# Altium schematic sheet MAIN.SchDoc(MAIN)
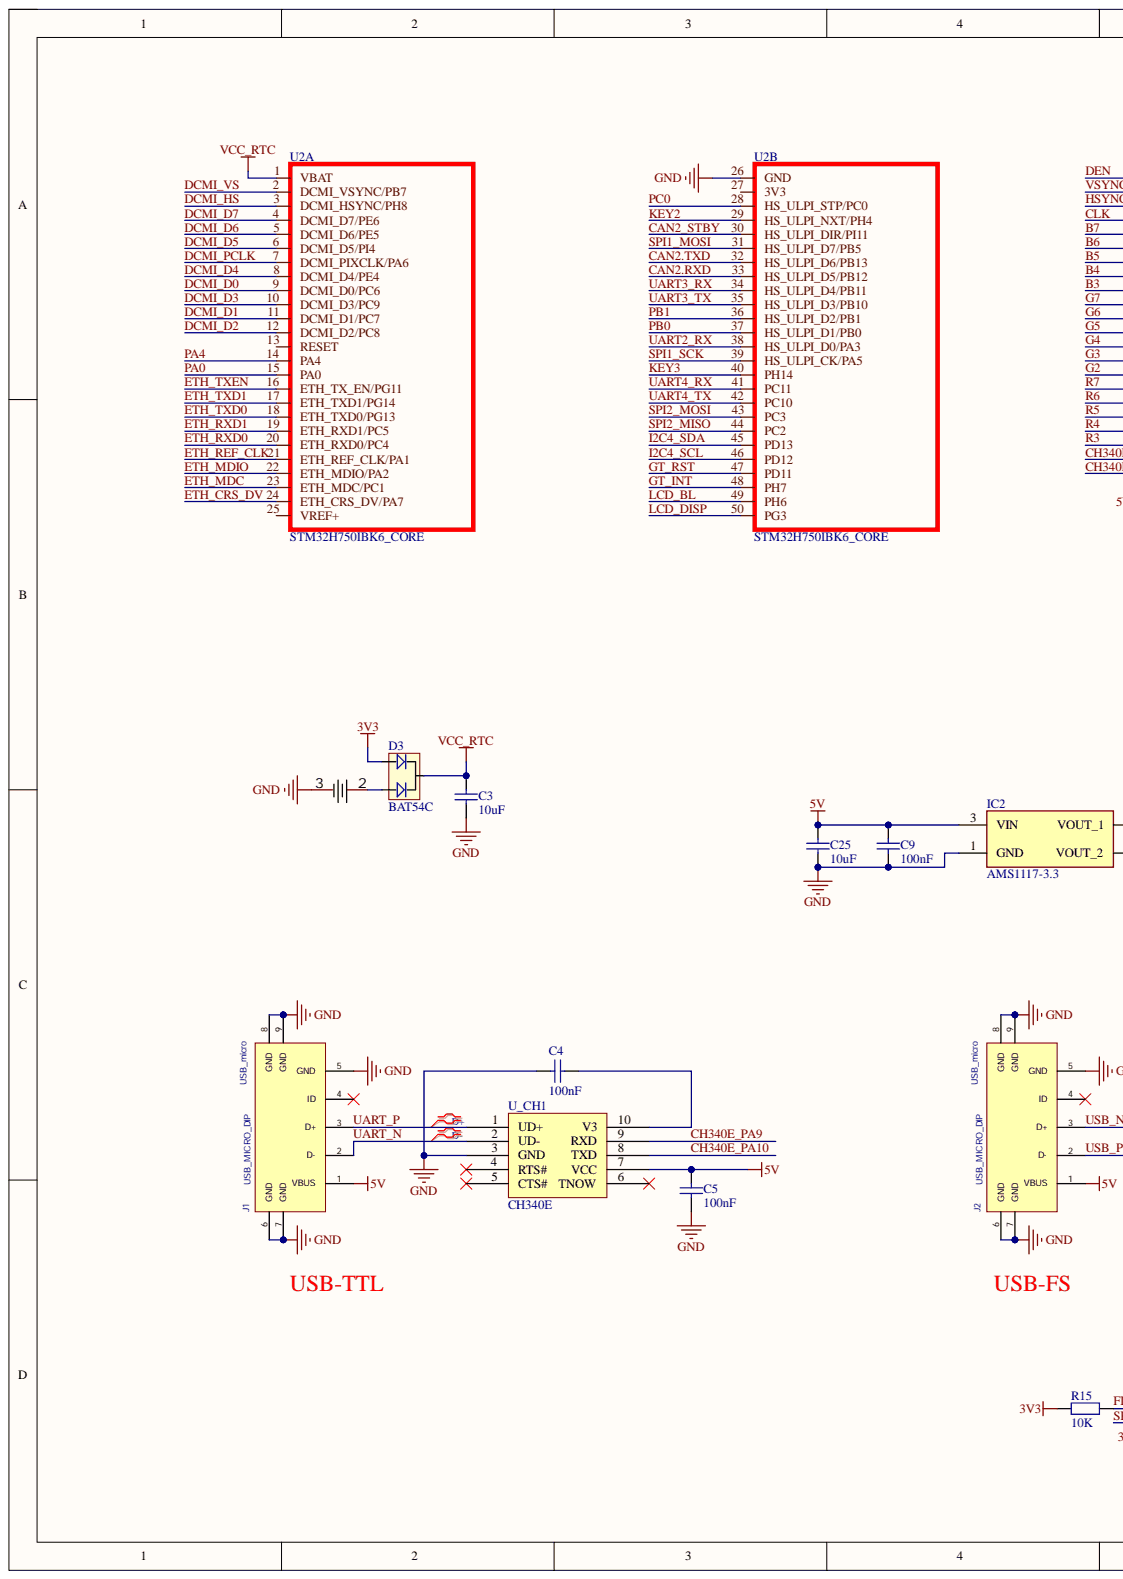
[diagram p0: MAIN.SchDoc, left]
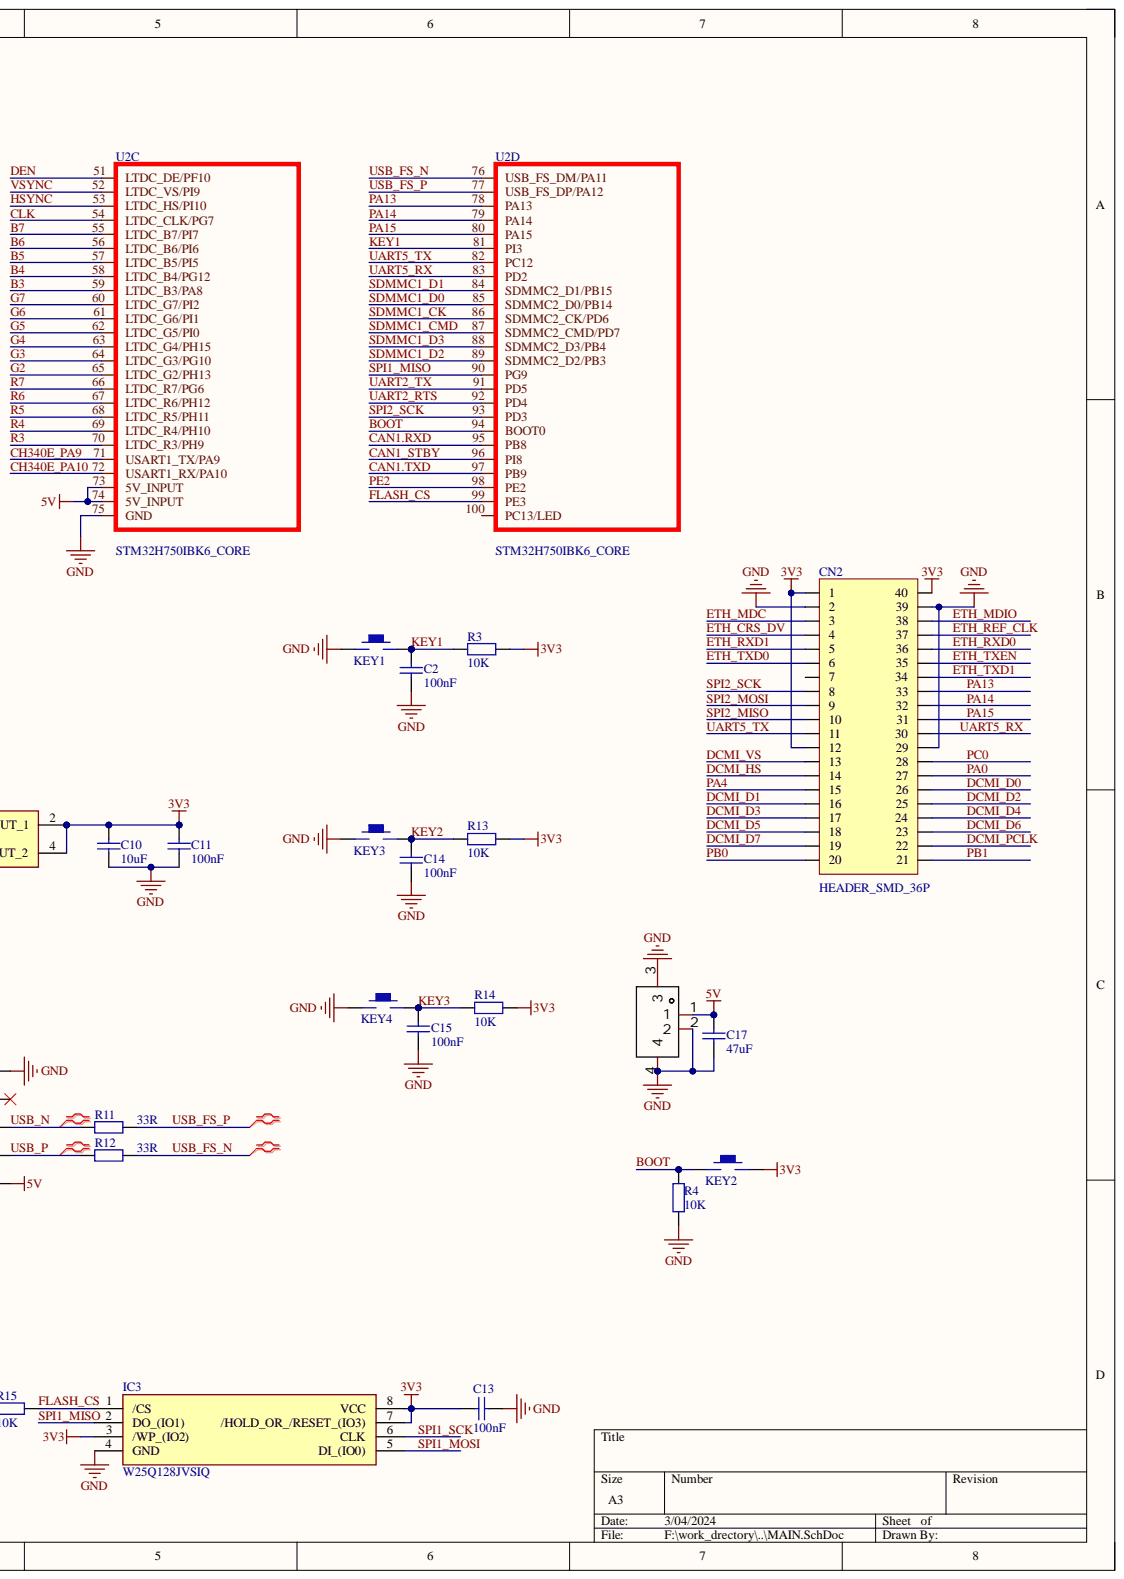
[diagram p1: MAIN.SchDoc, right]

## Parts
BT2  BS-06-B4AA002  [BAT_CR1220]  (value not drawn)
C2  100nF  [0402_C]
C3  10uF  [0603_C]
C4  100nF  [0402_C]
C5  100nF  [0402_C]
C9  100nF  [0402_C]
C10  10uF  [0603_C]
C11  100nF  [0402_C]
C13  100nF  [0402_C]
C14  100nF  [0402_C]
C15  100nF  [0402_C]
C17  47uF  [1206_C]
C25  10uF  [0603_C]
CN2  HEADER_SMD_36P  [HDR-SMD_40P-P2.54]
CN7  HC-1.25-2PWT  [CONN-SMD_2P-P1.25-HC-1.25-2PWT]  (value not drawn)
D3  BAT54C  [BAT54C215]
IC2  AMS1117-3.3  [SOT229P700X180-4N]
IC3  W25Q128JVSIQ  [SOP-8]
J1  USB_MICRO_DIP  value USB_micro  [MICRO_USB_5S]
J2  USB_MICRO_DIP  value USB_micro  [MICRO_USB_5S]
KEY1  KEY-SMD-324225  [KEY-SOP324225]  (value not drawn)
KEY2  KEY-SMD-324225  [KEY-SOP324225]  (value not drawn)
KEY3  KEY-SMD-324225  [KEY-SOP324225]  (value not drawn)
KEY4  KEY-SMD-324225  [KEY-SOP324225]  (value not drawn)
R3  10K  [0402_R]
R4  10K  [0402_R]
R11  33R  [0402_R]
R12  33R  [0402_R]
R13  10K  [0402_R]
R14  10K  [0402_R]
R15  10K  [0402_R]
U2  STM32H750IBK6_CORE  [CORE]  (parts A,B,C,D)
U_CH1  CH340E  [MSOP-10]

## Nets
3V3: C10.1 C11.1 C13.1 CN2.1 CN2.12 CN2.40 D3.1 IC2.2(VOUT_1) IC2.4(VOUT_2) IC3.3(/WP_(IO2)) IC3.7(/HOLD_OR_/RESET_(IO3)) IC3.8(VCC) KEY2.2 R3.1 R13.1 R14.1 R15.2
5V: C5.1 C9.1 C17.2 C25.1 CN7.1 IC2.3(VIN) J1.1(VBUS) J2.1(VBUS) U2.73(5V_INPUT) U2.74(5V_INPUT) U_CH1.7(VCC)
GND: BT2.3 C2.2 C3.2 C4.1 C5.2 C9.2 C10.2 C11.2 C13.2 C14.2 C15.2 C17.1 C25.2 CN2.2 CN2.29 CN2.39 CN7.2 CN7.3 CN7.4 IC2.1(GND) IC3.4(GND) J1.5(GND) J1.6(GND) J1.7(GND) J1.8(GND) J1.9(GND) J2.5(GND) J2.6(GND) J2.7(GND) J2.8(GND) J2.9(GND) KEY1.1 KEY3.1 KEY4.1 R4.2 U2.26(GND) U2.75(GND) U_CH1.3(GND)
VCC_RTC: C3.1 D3.3 U2.1(VBAT)
B3: U2.59(LTDC_B3/PA8)
B4: U2.58(LTDC_B4/PG12)
B5: U2.57(LTDC_B5/PI5)
B6: U2.56(LTDC_B6/PI6)
B7: U2.55(LTDC_B7/PI7)
BOOT: KEY2.1 R4.1 U2.94(BOOT0)
CAN1.RXD: U2.95(PB8) U2.96(PI8)  [also labelled CAN1_STBY]
CAN1.TXD: U2.97(PB9)
CAN2.RXD: U2.30(HS_ULPI_DIR/PI11) U2.33(HS_ULPI_D5/PB12)  [also labelled CAN2_STBY]
CAN2.TXD: U2.32(HS_ULPI_D6/PB13)
CH340E_PA9: U2.71(USART1_TX/PA9) U_CH1.9(RXD)
CH340E_PA10: U2.72(USART1_RX/PA10) U_CH1.8(TXD)
CLK: U2.54(LTDC_CLK/PG7)
DCMI_D0: CN2.26 U2.9(DCMI_D0/PC6)
DCMI_D1: CN2.16 U2.11(DCMI_D1/PC7)
DCMI_D2: CN2.25 U2.12(DCMI_D2/PC8)
DCMI_D3: CN2.17 U2.10(DCMI_D3/PC9)
DCMI_D4: CN2.24 U2.8(DCMI_D4/PE4)
DCMI_D5: CN2.18 U2.6(DCMI_D5/PI4)
DCMI_D6: CN2.23 U2.5(DCMI_D6/PE5)
DCMI_D7: CN2.19 U2.4(DCMI_D7/PE6)
DCMI_HS: CN2.14 U2.3(DCMI_HSYNC/PH8)
DCMI_PCLK: CN2.22 U2.7(DCMI_PIXCLK/PA6)
DCMI_VS: CN2.13 U2.2(DCMI_VSYNC/PB7)
DEN: U2.51(LTDC_DE/PF10)
ETH_CRS_DV: CN2.4 U2.24(ETH_CRS_DV/PA7)
ETH_MDC: CN2.3 U2.23(ETH_MDC/PC1)
ETH_MDIO: CN2.38 U2.22(ETH_MDIO/PA2)
ETH_REF_CLK: CN2.37 U2.21(ETH_REF_CLK/PA1)
ETH_RXD0: CN2.36 U2.20(ETH_RXD0/PC4)
ETH_RXD1: CN2.5 U2.19(ETH_RXD1/PC5)
ETH_TXD0: CN2.6 U2.18(ETH_TXD0/PG13)
ETH_TXD1: CN2.34 U2.17(ETH_TXD1/PG14)
ETH_TXEN: CN2.35 U2.16(ETH_TX_EN/PG11)
FLASH_CS: IC3.1(/CS) R15.1 U2.99(PE3)
G2: U2.65(LTDC_G2/PH13)
G3: U2.64(LTDC_G3/PG10)
G4: U2.63(LTDC_G4/PH15)
G5: U2.62(LTDC_G5/PI0)
G6: U2.61(LTDC_G6/PI1)
G7: U2.60(LTDC_G7/PI2)
GT_INT: U2.48(PH7)
GT_RST: U2.47(PD11)
HSYNC: U2.53(LTDC_HS/PI10)
I2C4_SCL: U2.46(PD12)
I2C4_SDA: U2.45(PD13)
KEY1: C2.1 KEY1.2 R3.2 U2.81(PI3)
KEY2: C14.1 KEY3.2 R13.2 U2.29(HS_ULPI_NXT/PH4)
KEY3: C15.1 KEY4.2 R14.2 U2.40(PH14)
LCD_BL: U2.49(PH6)
LCD_DISP: U2.50(PG3)
PA0: CN2.27 U2.15(PA0)
PA4: CN2.15 U2.14(PA4)
PA13: CN2.33 U2.78(PA13)
PA14: CN2.32 U2.79(PA14)
PA15: CN2.31 U2.80(PA15)
PB0: CN2.20 U2.37(HS_ULPI_D1/PB0)
PB1: CN2.21 U2.36(HS_ULPI_D2/PB1)
PC0: CN2.28 U2.28(HS_ULPI_STP/PC0)
PE2: U2.98(PE2)
R3: U2.70(LTDC_R3/PH9)
R4: U2.69(LTDC_R4/PH10)
R5: U2.68(LTDC_R5/PH11)
R6: U2.67(LTDC_R6/PH12)
R7: U2.66(LTDC_R7/PG6)
SDMMC1_CK: U2.86(SDMMC2_CK/PD6)
SDMMC1_CMD: U2.87(SDMMC2_CMD/PD7)
SDMMC1_D0: U2.85(SDMMC2_D0/PB14)
SDMMC1_D1: U2.84(SDMMC2_D1/PB15)
SDMMC1_D2: U2.89(SDMMC2_D2/PB3)
SDMMC1_D3: U2.88(SDMMC2_D3/PB4)
SPI1_MISO: IC3.2(DO_(IO1)) U2.90(PG9)
SPI1_MOSI: IC3.5(DI_(IO0)) U2.31(HS_ULPI_D7/PB5)
SPI1_SCK: IC3.6(CLK) U2.39(HS_ULPI_CK/PA5)
SPI2_MISO: CN2.10 U2.44(PC2)
SPI2_MOSI: CN2.9 U2.43(PC3)
SPI2_SCK: CN2.8 U2.93(PD3)
UART2_RTS: U2.92(PD4)
UART2_RX: U2.38(HS_ULPI_D0/PA3)
UART2_TX: U2.91(PD5)
UART3_RX: U2.34(HS_ULPI_D4/PB11)
UART3_TX: U2.35(HS_ULPI_D3/PB10)
UART4_RX: U2.41(PC11)
UART4_TX: U2.42(PC10)
UART5_RX: CN2.30 U2.83(PD2)
UART5_TX: CN2.11 U2.82(PC12)
UART_N: J1.2(D-) U_CH1.2(UD-)
UART_P: J1.3(D+) U_CH1.1(UD+)
USB_FS_N: R12.1 U2.76(USB_FS_DM/PA11)
USB_FS_P: R11.1 U2.77(USB_FS_DP/PA12)
USB_N: J2.3(D+) R11.2
USB_P: J2.2(D-) R12.2
VSYNC: U2.52(LTDC_VS/PI9)
NetBT2_2: BT2.2 D3.2
NetC4_2: C4.2 U_CH1.10(V3)

Not connected: CN2 7; J1 4(ID); J2 4(ID); U2 13(RESET) 25(VREF+) 27(3V3) 100(PC13/LED); U_CH1 4(RTS#) 5(CTS#) 6(TNOW)

## Library data of the parts
BT2 = BAT_HOLDER: Manufacturer: MYOUNG(美阳); Add into BOM: yes; Battery Type: CR2032; Connector Type: 电池底座; Component Kind: Standard; Convert to PCB: yes; Datasheet: https://atta.szlcsc.com/upload/public/pdf/source/20201218/C964756_801287CA93BF8BF80FAF4AB66101D13D.pdf; Device: BS-06-B4AA002; JLCPCB Part Class: Extended Part; LCSC Part Name: 电池底座 适用电池:CR2032 白色; Library Name: MY_LIB.SchLib; Manufacturer Part: BS-06-B4AA002; Name: BS-06-B4AA002; Operating Temperature Range: -25℃~+85℃; Supplier: LCSC; Supplier Footprint: SMD; Supplier Part: C964756; Symbol: BS-06-B4AA002
C2-C5 C9-C11 C13-C15 C25 = Cap: 25v; Component Kind: Standard; ComponentLink1Description: 供应商链接; ComponentLink1URL: http://www.szlcsc.com/product/details_1875.html; Library Name: MY_LIB.SchLib; Package: 0402; Supplier: LC; SuppliersPartNumber: C1523
C17 = Cap: 贴片电容; Component Kind: Standard; ComponentLink1Description: 供应商链接; ComponentLink1URL: http://www.szlcsc.com/product/details_1875.html; Library Name: MY_LIB.SchLib; Package: 0402; Supplier: LC; SuppliersPartNumber: C1523
CN2 = HEADER_SMD_40P: Component Kind: Standard; Library Name: MY_LIB.SchLib
CN7 = HC-1.25-2PWT: Manufacturer: HCTL(华灿天禄); Add into BOM: yes; Current Rating (Max): 1A; Gender: 公型插针; Number of PINs Per Row: 2; Pins Structure: 1x2P; Pitch: 1.25mm; Component Kind: Standard; Convert to PCB: yes; Datasheet: https://atta.szlcsc.com/upload/public/pdf/source/20210728/C2845379_48B7DC28A4B35DB030A7253F7C3FD974.pdf; Device: HC-1.25-2PWT; JLCPCB Part Class: Extended Part; LCSC Part Name: 2P 卧贴 间距P=1.25mm; Library Name: MY_LIB.SchLib; Manufacturer Part: HC-1.25-2PWT; Mounting Style: 卧贴; Name: HC-1.25-2PWT; Number of Rows: 1; Operating Temperature Range: -25℃~+85℃; Reference Series: -; Row Spacing: -; Supplier: LCSC; Supplier Footprint: SMD,P=1.25mm; Supplier Part: C2845379; Symbol: HC-1.25-2PWT
D3 = BAT54C: 双肖特基二极管; Component Kind: Standard; Library Name: MY_LIB.SchLib
IC2 = AMS1117-3.3: Integrated Circuit; Manufacturer_Name: Advanced Monolithic Systems; Component Kind: Standard; Datasheet Link: http://www.advanced-monolithic.com/pdf/ds1117.pdf; Height: 1.8mm; Library Name: MY_LIB.SchLib; Manufacturer_Part_Number: AMS1117-3.3
IC3 = W25Q128JVSIQ: Manufacturer_Name: Winbond; Manufacturer_Part_Number: W25Q128FVSIG; Arrow Part Number: W25Q128FVSIG; Arrow Price/Stock: https://www.arrow.com/en/products/w25q128fvsig/winbond-electronics; Component Kind: Standard; Datasheet Link: https://www.winbond.com/resource-files/w25q128fv rev.m 05132016 kms.pdf; Height: 2.16mm; Library Name: MY_LIB.SchLib; Mouser Part Number: N/A; Mouser Price/Stock: https://www.mouser.co.uk/ProductDetail/Winbond/W25Q128FVSIG?qs=rkhjVJ6%2F3EIwu4pV702YLQ%3D%3D
KEY1-KEY4 = KEY-SMD-324225: KEY,SMD,324225; Component Kind: Standard; Library Name: MY_LIB.SchLib
R3 R4 R11-R15 = Res: 贴片电阻; value: 0Ω; Component Kind: Standard; ComponentLink1Description: 供应商链接; ComponentLink1URL: http://www.szlcsc.com/product/details_17853.html; Library Name: MY_LIB.SchLib; Package: 0402; Supplier: LC; SuppliersPartNumber: C17168
J1 J2 = USB_MICRO_DIP: Component Kind: Standard; Library Name: MY_LIB.SchLib; PCB DECAL: MICRO_USB_5S
U2 = STM32H750IBK6_CORE: Component Kind: Standard; Library Name: MY_LIB.SchLib
U_CH1 = CH340E: Component Kind: Standard; Library Name: MY_LIB.SchLib
